# Altium schematic sheet Sche_cpu.SchDoc(Sche_cpu)
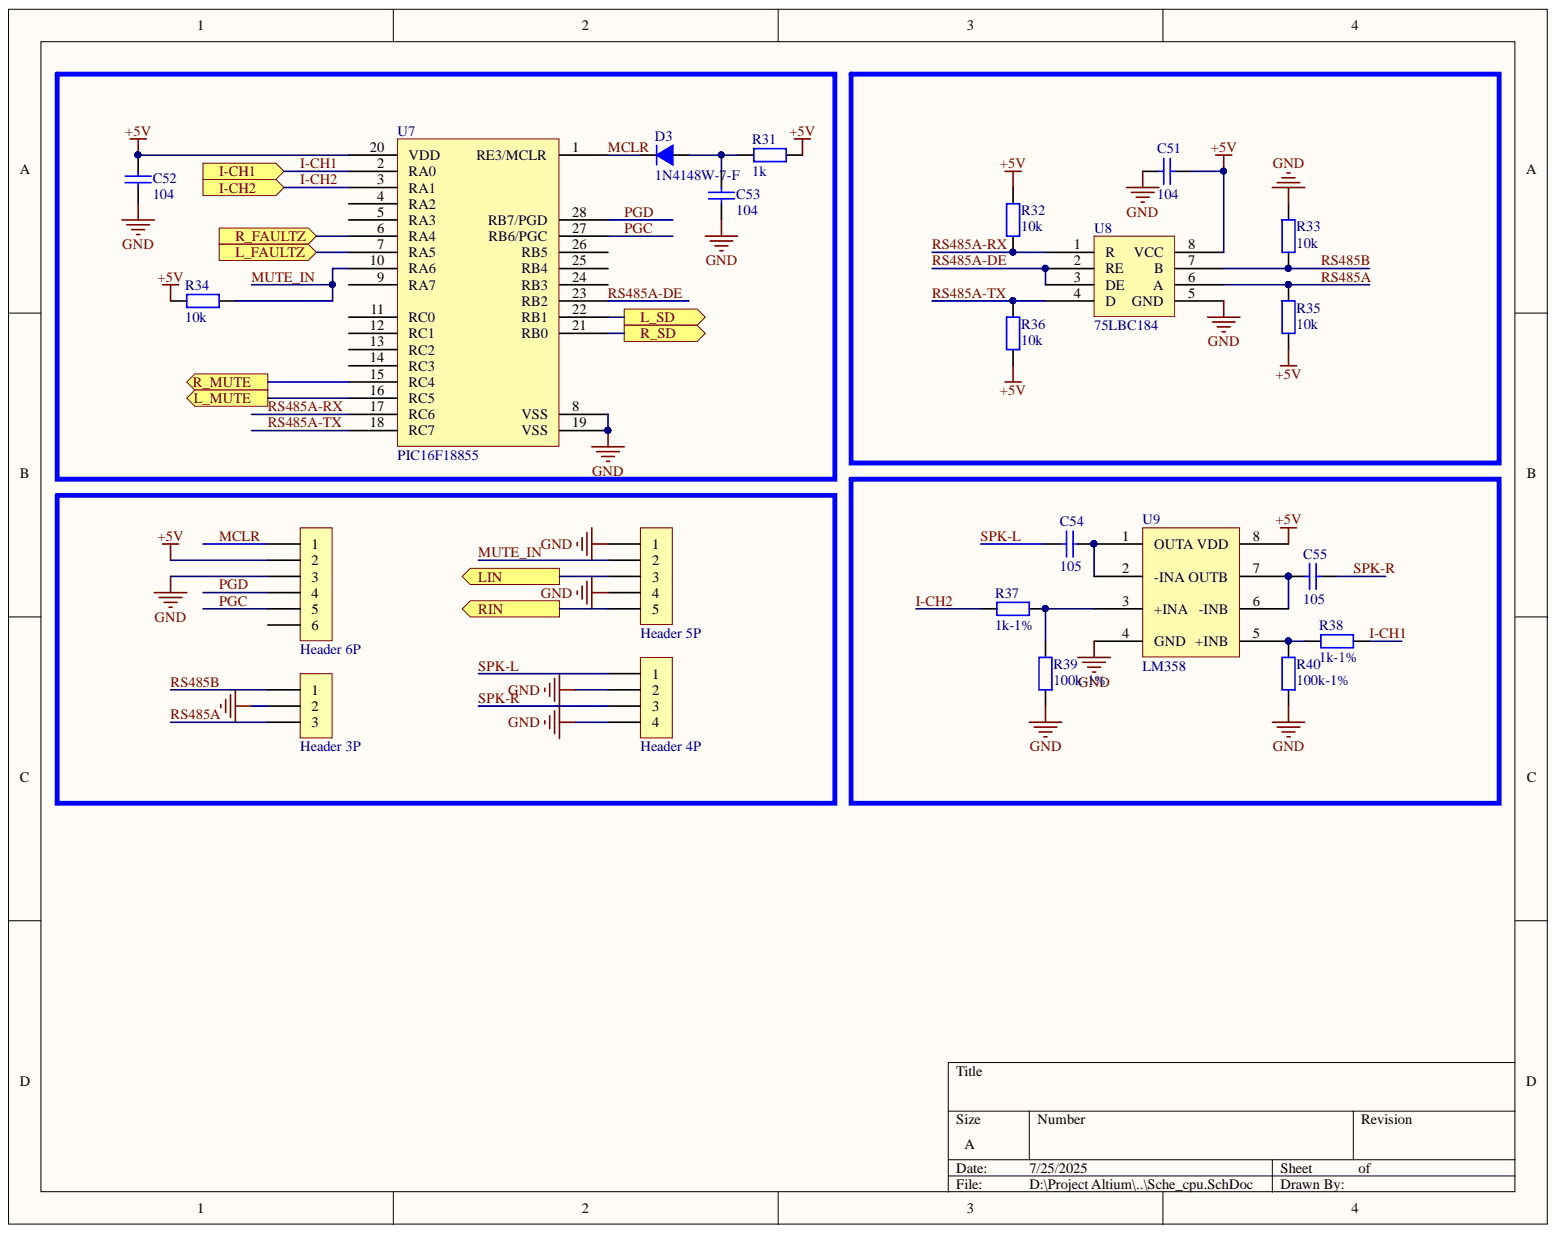
[diagram p0: Sche_cpu.SchDoc]

## Parts
C51  104  [tu 0603]
C52  104  [tu 0603]
C53  104  [tu 0603]
C54  105  [tu 0603]
C55  105  [tu 0603]
D3  1N4148W-7-F  [1N4148W-7-F]
H1  Header 6P  [XH2.54M-6]
H2  Header 5P  [XH2.54M-5]
H3  Header 4P  [XH2.54M-4]
H4  Header 3P  [XH2.54M-3]
R31  1k  [tro smd 0603]
R32  10k  [tro smd 0603]
R33  10k  [tro smd 0603]
R34  10k  [tro smd 0603]
R35  10k  [tro smd 0603]
R36  10k  [tro smd 0603]
R37  1k-1%  [tro smd 0603]
R38  1k-1%  [tro smd 0603]
R39  100k-1%  [tro smd 0603]
R40  100k-1%  [tro smd 0603]
U7  PIC16F18855  [PIC16F18855]
U8  75LBC184  [75LBC84]
U9  LM358  [LM358SMD]

## Ports
I-CH1, I-CH2, L_FAULTZ, L_MUTE, L_SD, LIN, R_FAULTZ, R_MUTE, R_SD, RIN

## Nets
+5V: C51.1 C52.1 H1.2 R31.2 R32.1 R34.1 R35.2 R36.2 U7.20(VDD) U8.8(VCC) U9.8(VDD)
GND: C51.2 C52.2 C53.2 H1.3 H2.1 H2.4 H3.2 H3.4 H4.2 R33.1 R39.1 R40.1 U7.8(VSS) U7.19(VSS) U8.5(GND) U9.4(GND)
I-CH1: R38.2 U7.2(RA0) ; port I-CH1
I-CH2: R37.1 U7.3(RA1) ; port I-CH2
L_FAULTZ: U7.7(RA5) ; port L_FAULTZ
L_MUTE: U7.16(RC5) ; port L_MUTE
L_SD: U7.22(RB1) ; port L_SD
LIN: H2.3 ; port LIN
MCLR: D3.2 H1.1 U7.1(RE3/MCLR)
MUTE_IN: H2.2 R34.2 U7.10(RA6)
PGC: H1.5 U7.27(RB6/PGC)
PGD: H1.4 U7.28(RB7/PGD)
R_FAULTZ: U7.6(RA4) ; port R_FAULTZ
R_MUTE: U7.15(RC4) ; port R_MUTE
R_SD: U7.21(RB0) ; port R_SD
RIN: H2.5 ; port RIN
RS485A: H4.3 R35.1 U8.6(A)
RS485A-DE: U7.23(RB2) U8.2(RE) U8.3(DE)
RS485A-RX: R32.2 U7.17(RC6) U8.1(R)
RS485A-TX: R36.1 U7.18(RC7) U8.4(D)
RS485B: H4.1 R33.2 U8.7(B)
SPK-L: C54.2 H3.1
SPK-R: C55.1 H3.3
NetC53_1: C53.1 D3.1 R31.1
NetC54_1: C54.1 U9.1(OUTA) U9.2(-INA)
NetC55_2: C55.2 U9.6(-INB) U9.7(OUTB)
NetR37_2: R37.2 R39.2 U9.3(+INA)
NetR38_1: R38.1 R40.2 U9.5(+INB)

Not connected: H1 6; U7 4(RA2) 5(RA3) 9(RA7) 11(RC0) 12(RC1) 13(RC2) 14(RC3) 24(RB3) 25(RB4) 26(RB5)

## Library data of the parts
C51-C53 = Tu 0603 104 50V 10%: Dán; Ten_Linh_Kien: Tụ 0603 104-100nF 10% 50V; So_Chan: 2; Ma_Hang: MH004568; Don_Vi: Con; Library Name: Tu dien.SchLib; Component Kind: Standard; Chung_Loai: Dán
C54 C55 = Tu 0603 105 50V 10%: Dán; Ten_Linh_Kien: Tụ 0603 105-1uF 50V 10%; So_Chan: 2; Ma_Hang: MH004569; Don_Vi: Con; Library Name: Tu dien.SchLib; Component Kind: Standard; Chung_Loai: Dán
D3 = 1N4148W-7-F: Diode 1n4148 smd; Library Name: test.SchLib; Component Kind: Standard
H1 = Header 6P 2.54 Duc Thang: Ten_Linh_Kien: Header 6P XH2.54-6P Đực Thẳng; Ma_Hang: MH001972; Don_Vi: Con; Chung_Loai: Cắm; Library Name: Header.SchLib; Component Kind: Standard
H2 = Header 5P 2.54 Duc Thang: Ten_Linh_Kien: Header 5P XH2.54-5P Đực Thẳng; Ma_Hang: MH001298; Don_Vi: Con; Chung_Loai: Cắm; Library Name: Header.SchLib; Component Kind: Standard
H3 = Header 4P 2.54 Duc Thang: Ten_Linh_Kien: Header 4P XH2.54-4P Đực Thẳng; Ma_Hang: MH001285; Don_Vi: Con; Chung_Loai: Cắm; Library Name: Header.SchLib; Component Kind: Standard
H4 = Header 3P 2.54 Duc Thang: Ten_Linh_Kien: Header 3P XH2.54-3P Đực Thẳng; Ma_Hang: MH001292; Don_Vi: Con; Chung_Loai: Cắm; Library Name: Header.SchLib; Component Kind: Standard
R31 R37 R38 = Tro 0603 1% 1k: Dán; Ten_Linh_Kien: Trở 0603 1% 1k; So_Chan: 2; Ma_Hang: MH006334; Don_Vi: Con; Library Name: Dien Tro.SchLib; Component Kind: Standard; Chung_Loai: Dán
R32-R36 = Tro 0603 1% 10k: Dán; Ten_Linh_Kien: Trở 0603 1% 10k; So_Chan: 2; Ma_Hang: MH006333; Don_Vi: Con; Library Name: Dien Tro.SchLib; Component Kind: Standard; Chung_Loai: Dán
R39 R40 = Tro 0603 5% 100k: Dán; Ten_Linh_Kien: Trở 0603 5% 100k; So_Chan: 2; Ma_Hang: MH006314; Don_Vi: Con; Library Name: Dien Tro.SchLib; Component Kind: Standard; Chung_Loai: Dán
U7 = PIC16F18855: PIC16F1855; Library Name: test.SchLib; Component Kind: Standard
U8 = 75LBC184: Library Name: test.SchLib; Component Kind: Standard
U9 = LM358: Library Name: test.SchLib; Component Kind: Standard
